# Altium schematic sheet pboost.SchDoc(pboost)
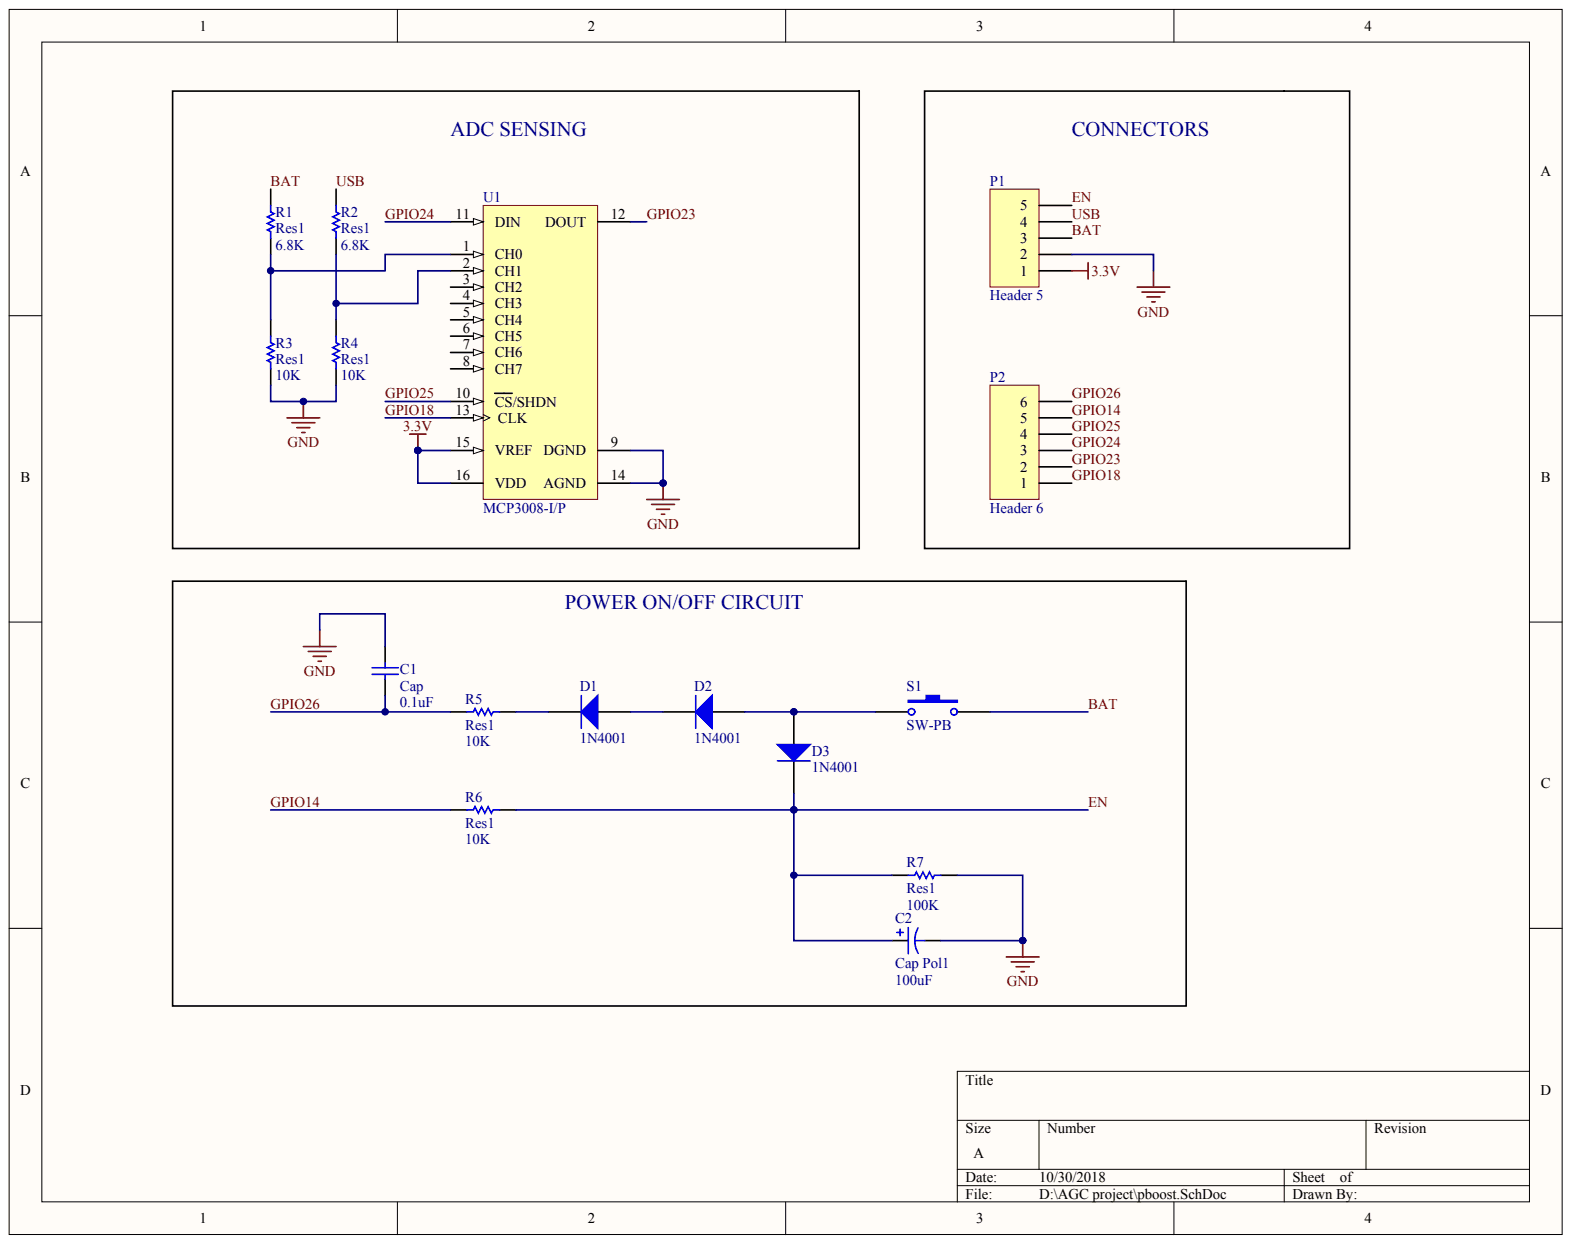
[diagram p0: pboost.SchDoc]

## Parts
C1  Cap  value 0.1uF
C2  Cap Pol1  value 100uF
D1  1N4001
D2  1N4001
D3  1N4001
P1  Header 5
P2  Header 6
R1  Res1  value 6.8K
R2  Res1  value 6.8K
R3  Res1  value 10K
R4  Res1  value 10K
R5  Res1  value 10K
R6  Res1  value 10K
R7  Res1  value 100K
S1  SW-PB
U1  MCP3008-I/P

## Nets
3.3V: P1.1 U1.15(VREF) U1.16(VDD)
GND: C1.2 C2.2 P1.2 R3.1 R4.1 R7.2 U1.9(DGND) U1.14(AGND)
BAT: P1.3 R1.2 S1.2
EN: C2.1 D3.2 P1.5 R6.2 R7.1
GPIO14: P2.5 R6.1
GPIO18: P2.1 U1.13(CLK)
GPIO23: P2.2 U1.12(DOUT)
GPIO24: P2.3 U1.11(DIN)
GPIO25: P2.4 U1.10(CS/SHDN)
GPIO26: C1.1 P2.6 R5.1
USB: P1.4 R2.2
NetD1_1: D1.1 D2.2
NetD1_2: D1.2 R5.2
NetD2_1: D2.1 D3.1 S1.1
NetR1_1: R1.1 R3.2 U1.1(CH0)
NetR2_1: R2.1 R4.2 U1.2(CH1)

Not connected: U1 3(CH2) 4(CH3) 5(CH4) 6(CH5) 7(CH6) 8(CH7)

## Library data of the parts
R7 = (no symbol name): Value: 100K; Published: 8-Jun-2000; LatestRevisionDate: 17-Jul-2002; LatestRevisionNote: Re-released for DXP Platform.; PackageReference: AXIAL-0.3; PackageDescription: Axial Device, Thru-Hole; 2 Leads; 0.3 in Pin Spacing; Publisher: Altium Limited
C1 C2 D1-D3 P1 P2 R1-R6 S1 U1 = (no symbol name): Publisher: Altium Limited
  C1: Published: 8-Jun-2000; LatestRevisionDate: 17-Jul-2002; LatestRevisionNote: Re-released for DXP Platform.; PackageReference: RAD-0.3; PackageDescription: Radial Cap, Thru-Hole; 2 Leads; 0.3 in Pin Spacing
  C2: Published: 8-Jun-2000; LatestRevisionDate: 17-Jul-2002; LatestRevisionNote: Re-released for DXP Platform.; PackageReference: RB7.6-15; PackageDescription: Polarized Capacitor (Radial); 2 Leads
  D1-D3: Published: 8-Jun-2000; LatestRevisionDate: 17-Jul-2002; LatestRevisionNote: Re-released for DXP Platform.; DatasheetDocument: 1997; PackageReference: DO-35; Code_JEDEC: DO-204-AH; ComponentLink1Description: Manufacturer Link; ComponentLink1URL: http://www.fairchildsemi.com/; ComponentLink2Description: Datasheet; ComponentLink2URL: http://www.fairchildsemi.com/ds/1N%2F1N4148.pdf
  P1 P2: LatestRevisionDate: 17-Jul-2002; LatestRevisionNote: Re-released for DXP Platform.
  R1-R6: Published: 8-Jun-2000; LatestRevisionDate: 17-Jul-2002; LatestRevisionNote: Re-released for DXP Platform.; PackageReference: AXIAL-0.3; PackageDescription: Axial Device, Thru-Hole; 2 Leads; 0.3 in Pin Spacing
  S1: Published: 24-Mar-1999; LatestRevisionDate: 17-Jul-2002; LatestRevisionNote: Re-released for DXP Platform.; PackageReference: SPST-2; PackageDescription: Switch; 2 Leads
  U1: Published: 29-Jan-2009; LatestRevisionDate: 02-Dec-2009; LatestRevisionNote: Updated to datasheet revision D, Dec-2008; DatasheetVersion: revD, Dec-2008; PackageVersion: revBB, Aug-2009; PackageReference: PDIP300-P16; PackageDescription: 16-Lead Plastic Dual In-Line (P) - 300 mil Body [PDIP]; Supplier 1: Digi-Key; Supplier Part Number 1: MCP3008-I/P-ND; Supplier 2: Farnell; Supplier Part Number 2: 1627174; Code_JEDEC: MS-001-BB; ComponentLink1Description: Datasheet; ComponentLink1URL: http://ww1.microchip.com/downloads/en/DeviceDoc/21295d.pdf; ComponentLink2Description: Packaging Specification; ComponentLink2URL: http://www.microchip.com/stellent/groups/techpub_sg/documents/packagingspec/en012702.pdf; ComponentLink3Description: Microchip.com Home; ComponentLink3URL: http://www.microchip.com/
